# Altium schematic sheet Sensor.SchDoc
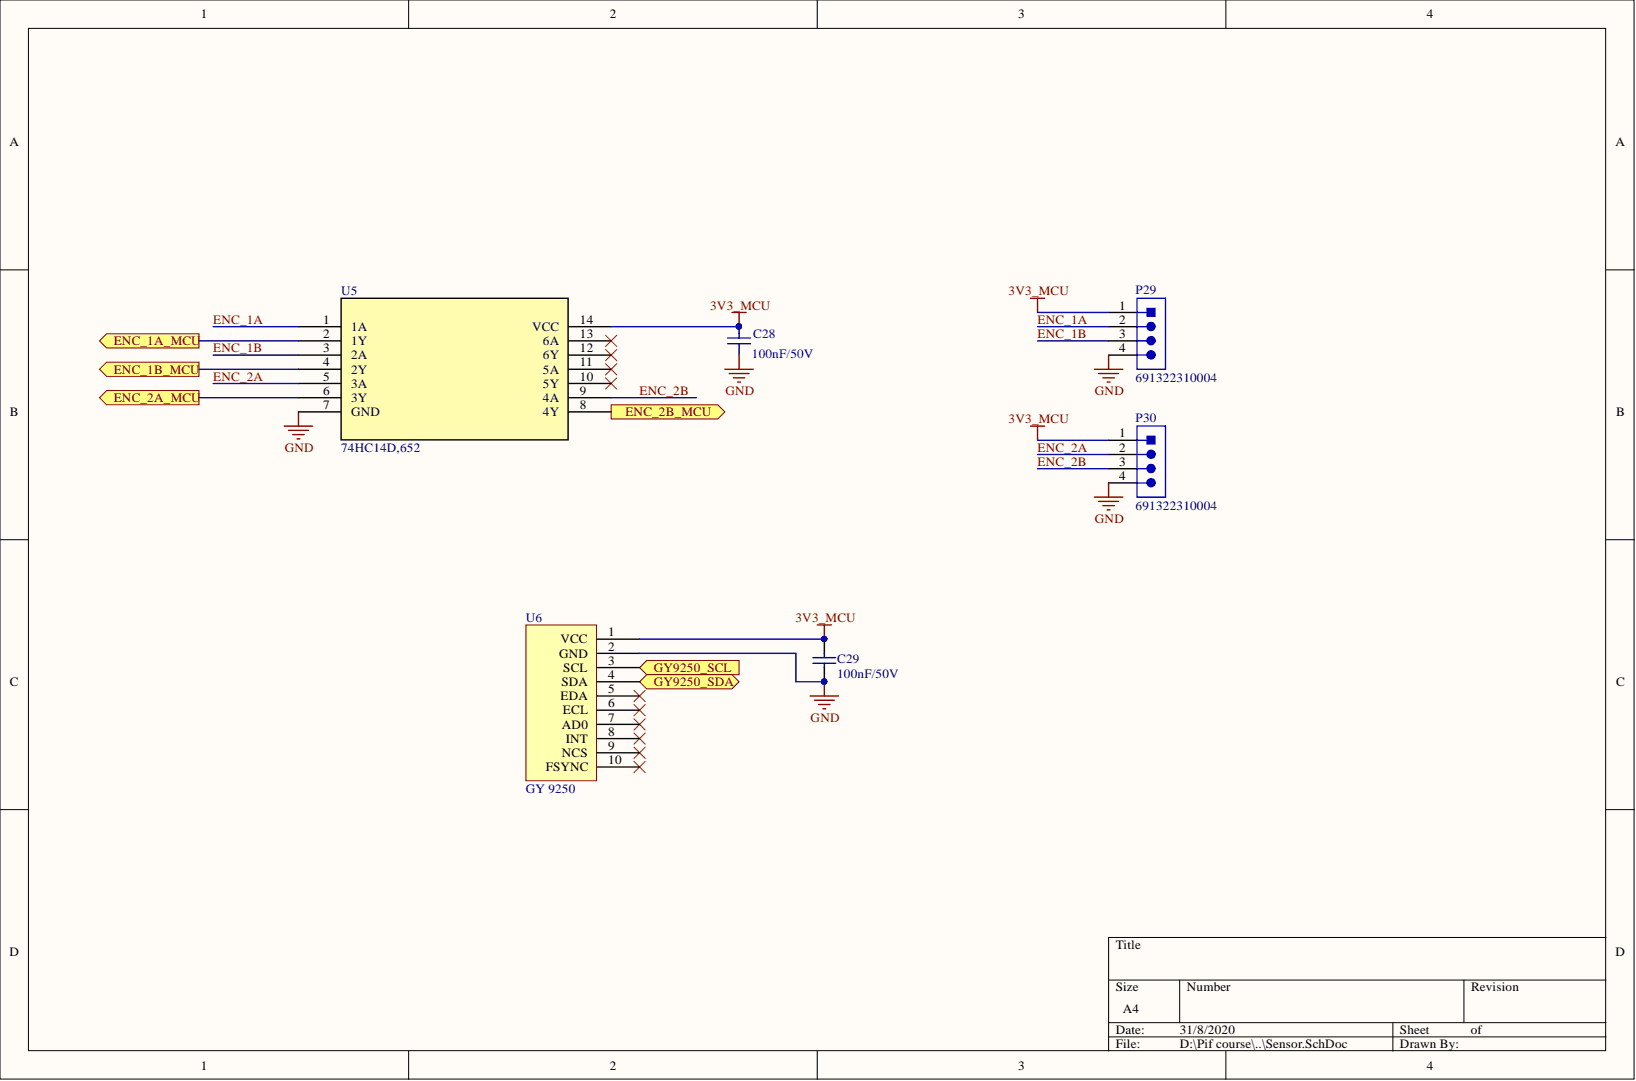
[diagram p0: Sensor.SchDoc]

## Parts
C28  value 100nF/50V  [CAP-SM-0805]  (hidden comment: Cap Non-Pol SMD)
C29  value 100nF/50V  [CAP-SM-0805]  (hidden comment: Cap-1nF-50V-0805)
P29  691322310004  [691322310004]
P30  691322310004  [691322310004]
U5  74HC14D,652  [74HC14D,652]
U6  GY 9250  [GY 9250]

## Ports
ENC_1A_MCU, ENC_1B_MCU, ENC_2A_MCU, ENC_2B_MCU, GY9250_SCL, GY9250_SDA

## Nets
3V3_MCU: C28.2 C29.2 P29.1 P30.1 U5.14(VCC) U6.1(VCC)
GND: C28.1 C29.1 P29.4 P30.4 U5.7(GND) U6.2(GND)
ENC_1A: P29.2 U5.1(1A)
ENC_1A_MCU: U5.2(1Y) ; port ENC_1A_MCU
ENC_1B: P29.3 U5.3(2A)
ENC_1B_MCU: U5.4(2Y) ; port ENC_1B_MCU
ENC_2A: P30.2 U5.5(3A)
ENC_2A_MCU: U5.6(3Y) ; port ENC_2A_MCU
ENC_2B: P30.3 U5.9(4A)
ENC_2B_MCU: U5.8(4Y) ; port ENC_2B_MCU
GY9250_SCL: U6.3(SCL) ; port GY9250_SCL
GY9250_SDA: U6.4(SDA) ; port GY9250_SDA

Not connected: U5 10(5Y) 11(5A) 12(6Y) 13(6A); U6 5(EDA) 6(ECL) 7(AD0) 8(INT) 9(NCS) 10(FSYNC)

## Library data of the parts
C28 = Cap Non-Pol SMD: Capacitor; Component Kind: Standard; Library Name: PIF_C16_lib.IntLib
C29 = Cap-1nF-50V-0805: CAP CER 1000PF 100V X7R 0805; Manufacturer: Johanson Dielectrics Inc.; Manufacturer Part Number: 101X15W102MV4E; Component Kind: Standard; Library Name: Capacitor.SchLib; Local Supplier: thegioiic; Local Supplier Link: https://thegioiic.com/products/tu-1nf-50v-0805; Supplier 1: Digikey; Supplier 1 Link: https://www.digikey.com/product-detail/en/johanson-dielectrics-inc/101X15W102MV4E/709-1335-1-ND/2327235; Supplier 1 Part Number: 709-1335-1-ND
P29 P30 = 691322310004: WR-TBL Serie 322 Horizontal PCB Header, Pluggable, pitch 3.81mm, 4p; Compliance: RoHS; Modularity: No; Pin Number: 4; Rated Current [UL]: 10A; Working Voltage [UL]: 300VAC; Application: Pluggable; Component Kind: Standard; ComponentLink1Description: Manufacturer; ComponentLink1URL: http://www.we-online.de; ComponentLink2Description: Datasheet; ComponentLink2URL: https://katalog.we-online.de/em/datasheet/691322310004.pdf; Library Name: 691101710002_Altium_WR-TBL_rev19a.IntLib; Mounting Technology: THT; Operating Temperature: -40 to +105degC; Packaging: Box; Part Number: 691322310004; PCB/Cable/Panel: PCB; Pitch: 3.81mm; Published Date: October 2019; Type: Horizontal; Publisher: Wurth Elektronik
U5 = 74HC14D,652: SourceLibrary: Nexperia_2018-05-2018; Vendor: Nexperia; Component Kind: Standard; Library Name: Integrated_Library.IntLib; Manufacturer_Part_Number: 74HC14D,652; RefDes: RefDes; Type: DEV; Copyright: Copyright (C) 2016 Accelerated Designs. All rights reserved
U6 = GY 9250: Component Kind: Standard; Library Name: Integrated_Library.IntLib
